# Altium schematic sheet HBridge_PWM_Driver.SchDoc
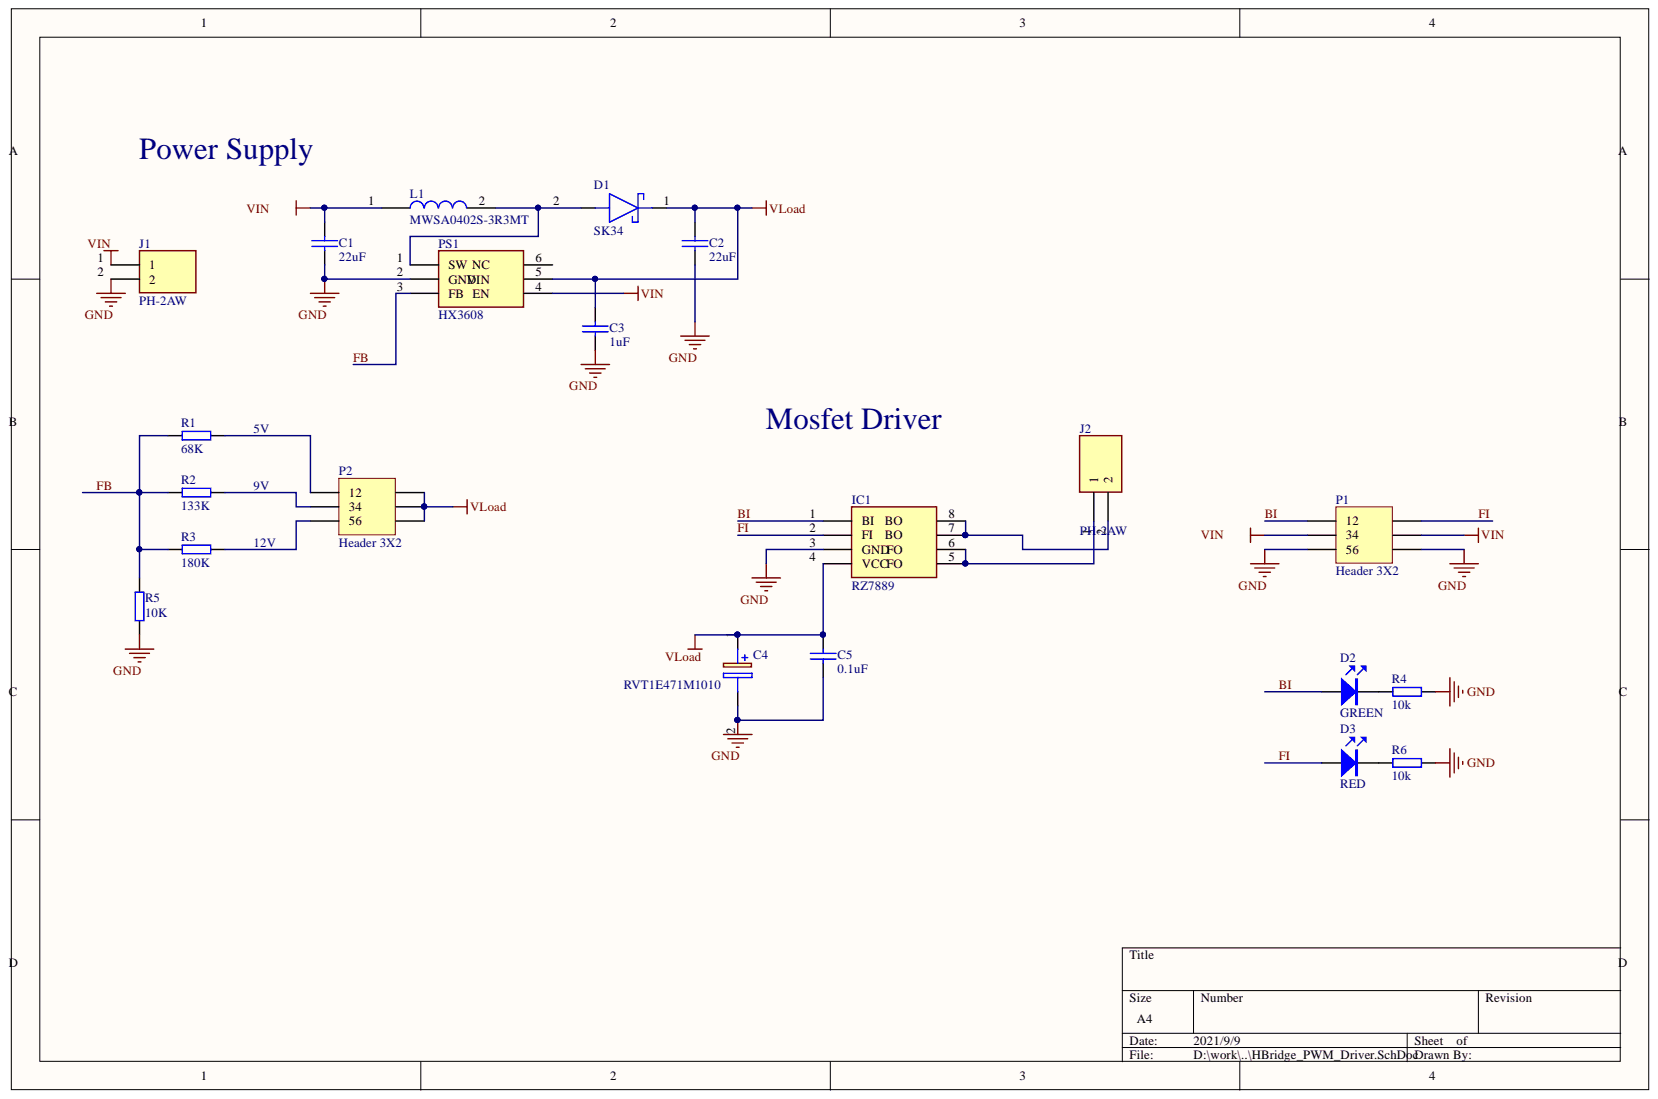
[diagram p0: HBridge_PWM_Driver.SchDoc]

## Parts
C1  22uF  [C1206]
C2  22uF  [C1206]
C3  1uF  [C0603]
C4  RVT1E471M1010  [CAPAE1030X1100N]
C5  0.1uF  [C0603]
D1  SK34  [DIOM5436X244N]
D2  GREEN  [LED_0603]
D3  RED  [LED_0603]
IC1  RZ7889  [SOIC127P600X175-8N]
J1  PH-2AW  [SHDR2W50P0X200_1X2_600X600X500P]
J2  PH-2AW  [SHDR2W50P0X200_1X2_600X600X500P]
L1  MWSA0402S-3R3MT  [INDPM4442X200N]
P1  Header 3X2  [HDR2X3]
P2  Header 3X2  [HDR2X3]
PS1  HX3608  [SOT95P280X125-6N]
R1  68K  [R0603]
R2  133K  [R0603]
R3  180K  [R0603]
R4  10k  [R0603]
R5  10K  [R0603]
R6  10k  [R0603]

## Nets
GND: C1.1 C2.1 C3.1 C4.2 C5.1 IC1.3(GND) J1.2 P1.5 P1.6 PS1.2(GND) R4.2 R5.2 R6.2
VIN: C1.2 J1.1 L1.1 P1.3 P1.4 PS1.4
VLOAD: C2.2 C3.2 C4.1 C5.2 D1.1 IC1.4(VCC) P2.2 P2.4 P2.6 PS1.5
BI: D2.1 IC1.1(BI) P1.1
FB: PS1.3(FB) R1.1 R2.1 R3.1 R5.1
FI: D3.1 IC1.2(FI) P1.2
NetD1_2: D1.2 L1.2 PS1.1
NetD2_2: D2.2 R4.1
NetD3_2: D3.2 R6.1
NetIC1_5: IC1.5 IC1.6 J2.1
NetIC1_7: IC1.7 IC1.8 J2.2
NetP2_1: P2.1 R1.2
NetP2_3: P2.3 R2.2
NetP2_5: P2.5 R3.2

Not connected: PS1 6

## Library data of the parts
C1 C2 = CAP: 25V X5R 10% GRM31CR61E226KE15L; Component Kind: Standard; Library Name: Component.SchLib
C3 C5 = CAP: 25V X5R; Component Kind: Standard; Library Name: Component.SchLib
C4 = RVT1E471M1010: Capacitor Polarised; Manufacturer_Name: ROQANG; Component Kind: Standard; Datasheet Link: https://datasheet.lcsc.com/szlcsc/2003311206_ROQANG-VT1E471M1010_C310844.pdf; Height: 11mm; Manufacturer_Part_Number: RVT1E471M1010
D1 = SK34: Schottky Diode; Manufacturer_Name: MDD; Component Kind: Standard; Datasheet Link: https://datasheet.lcsc.com/szlcsc/1903041730_MDD-Jiangsu-Yutai-Elec-SK34_C18895.pdf; Height: 2.44mm; Manufacturer_Part_Number: SK34
D2 D3 = LED: Component Kind: Standard; Description: LED; Library Name: Component.SchLib
IC1 = RZ7889: Motor Driver 3-15V 4.2A max; Component Kind: Standard; Library Name: SamacSys.SchLib
J1 J2 = PH-2AW: Connector; Manufacturer_Name: Boom Precision Electric; Component Kind: Standard; Datasheet Link: https://lcsc.com/product-detail/Wire-To-Board-Wire-To-Wire-Connector_Boom-Precision-Elec-PH-2AW-2P-pitch2-0mm-Lying-in_C16965.html; Height: 5mm; Manufacturer_Part_Number: PH-2AW
L1 = MWSA0402S-3R3MT: Inductor; Manufacturer_Name: SUNLORD; Component Kind: Standard; Datasheet Link: https://datasheet.lcsc.com/szlcsc/Sunlord-MWSA1004-3R3MT_C143963.pdf; Height: 2mm; Library Name: SamacSys.SchLib; Manufacturer_Part_Number: MWSA0402S-3R3MT
P1 P2 = Header 3X2: Header, 3-Pin, Dual row; Component Kind: Standard; LatestRevisionDate: 17-Jul-2002; LatestRevisionNote: Re-released for DXP Platform.; Library Name: Miscellaneous Connectors.IntLib; Signal Integrity: Connector; Publisher: Altium Limited
PS1 = HX3608: Power Supply; Manufacturer_Name: hengjiaxing; Component Kind: Standard; Datasheet Link: https://datasheet.lcsc.com/szlcsc/1811201211_hengjiaxing-HX3608_C296278.pdf; Height: 1.25mm; Manufacturer_Part_Number: HX3608
R1-R3 = RES: X5R 0603 1% 1/16W; Component Kind: Standard; Library Name: Component.SchLib
R4 R6 = RES: 电阻; Component Kind: Standard; Library Name: Component.SchLib
R5 = RES: X7R 0603 1% 1/16W; Component Kind: Standard; Library Name: Component.SchLib
